# Altium schematic sheet Liquid level detection_v2.SchDoc
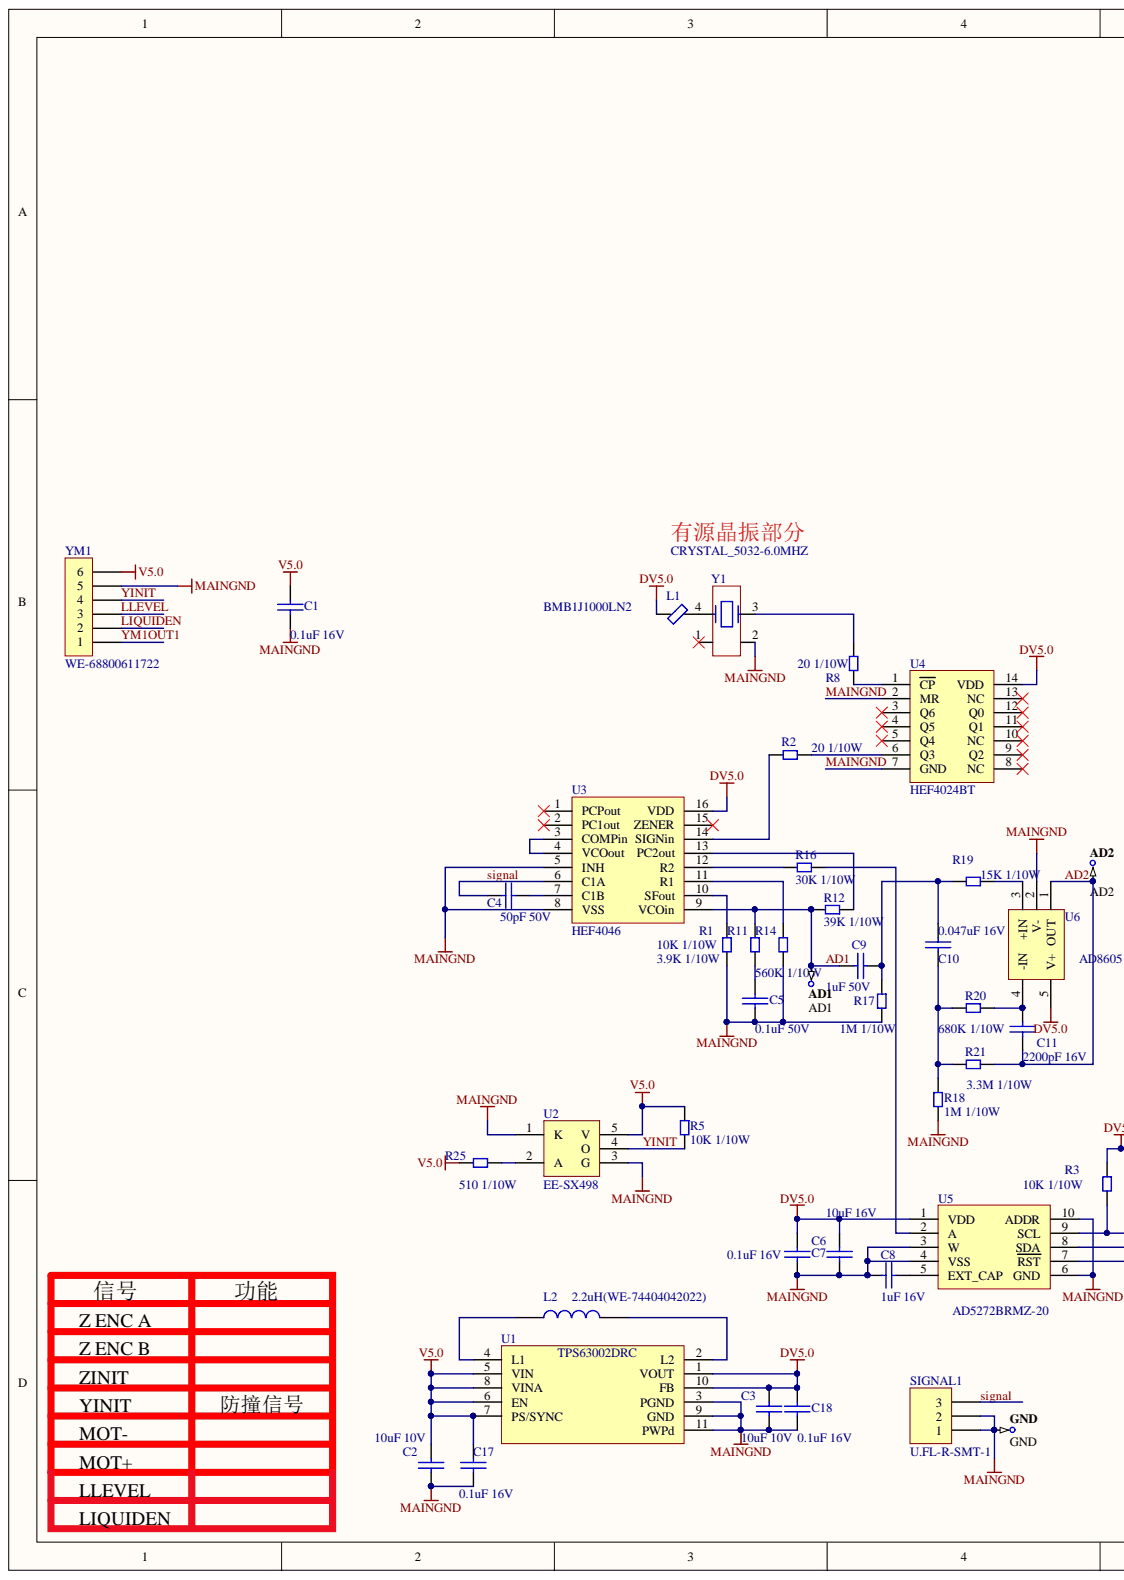
[diagram p0: Liquid level detection_v2.SchDoc, left]
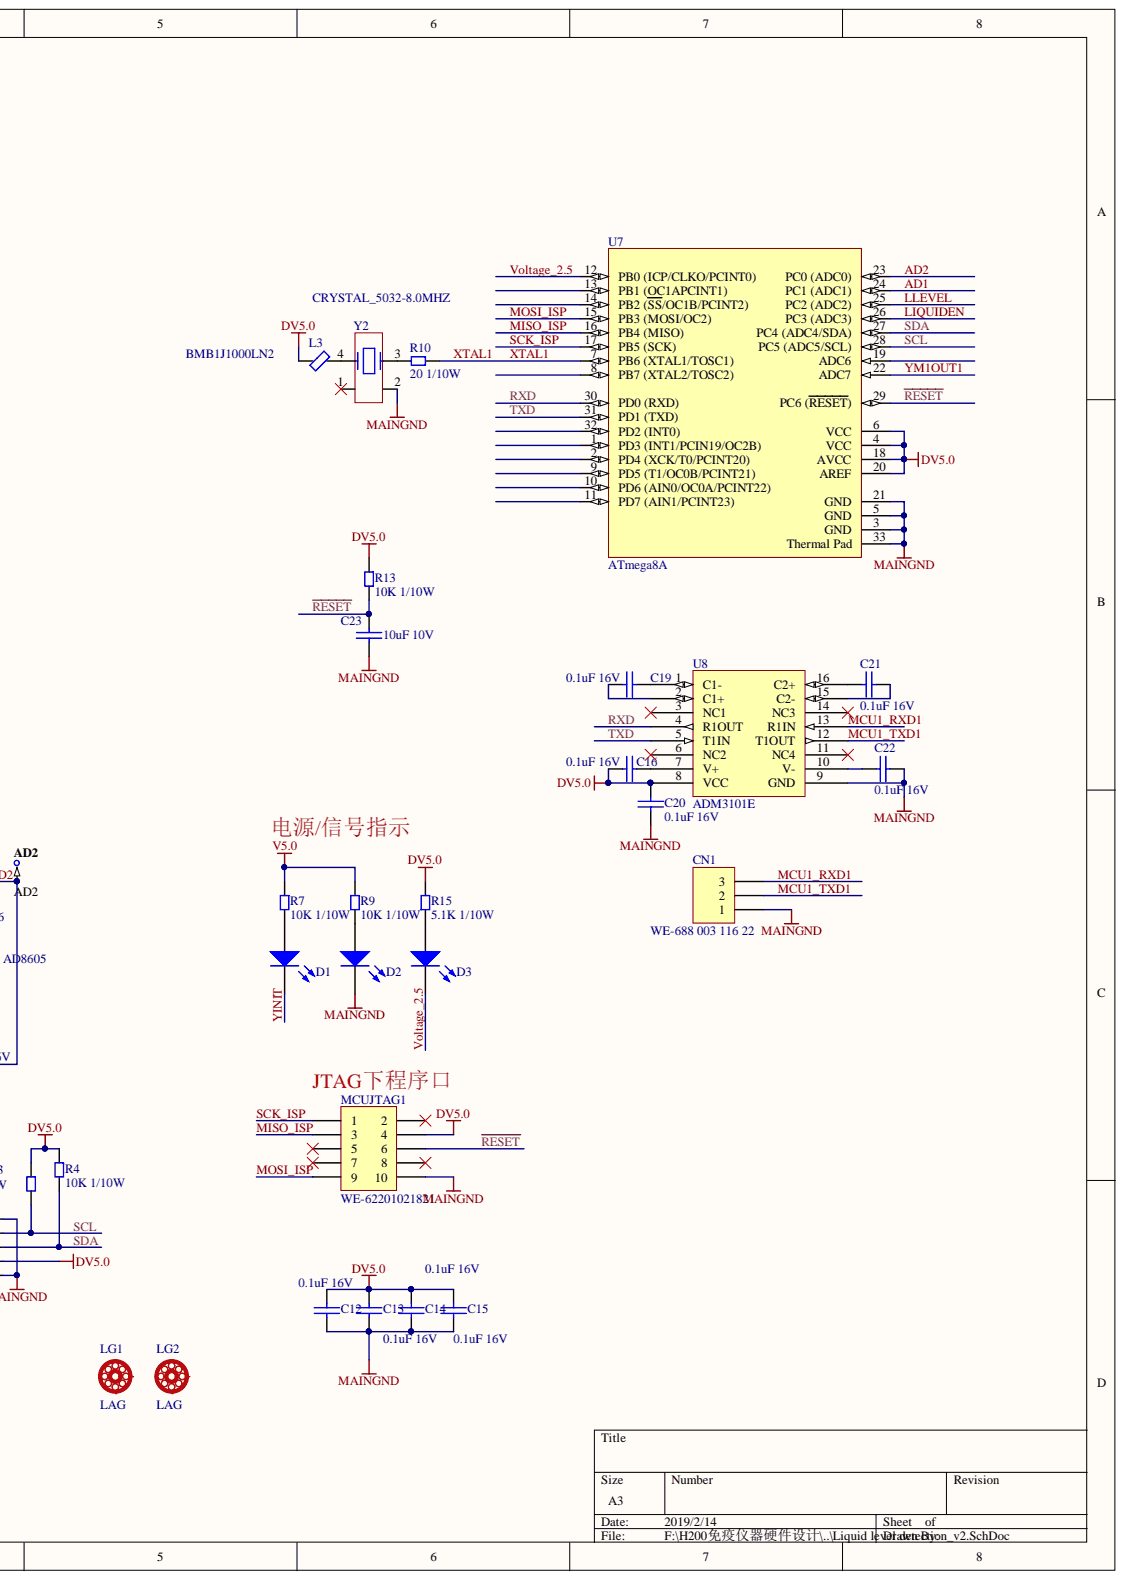
[diagram p1: Liquid level detection_v2.SchDoc, right]

## Parts
AD1  AD1  [TestPin]  (no BOM)
AD2  AD2  [TestPin]  (no BOM)
C1  0.1uF 16V  [C0603_104/50V]
C2  10uF 10V  [C0603_106/50V]
C3  10uF 10V  [C0603_106/50V]
C4  50pF 50V  [C0603_500/50V]
C5  0.1uF 50V  [C0603_104/50V]
C6  10uF 16V  [C0603_106/50V]
C7  0.1uF 16V  [C0603_104/50V]
C8  1uF 16V  [C0603_105/50V]
C9  1uF 50V  [C0603_104/50V]
C10  0.047uF 16V  [C0603_472/16V]
C11  2200pF 16V  [C0603_222/50V]
C12  0.1uF 16V  [C0603_104/50V]
C13  0.1uF 16V  [C0603_104/50V]
C14  0.1uF 16V  [C0603_104/50V]
C15  0.1uF 16V  [C0603_104/50V]
C16  0.1uF 16V  [C0603_104/50V]
C17  0.1uF 16V  [C0603_104/50V]
C18  0.1uF 16V  [C0603_104/50V]
C19  0.1uF 16V  [C0603_104/50V]
C20  0.1uF 16V  [C0603_104/50V]
C21  0.1uF 16V  [C0603_104/50V]
C22  0.1uF 16V  [C0603_104/50V]
C23  10uF 10V  [C0603_106/50V]
CN1  WE-688 003 116 22  [WR-WTB-2.5mm-3pin_68800311622]
D1  LED2  [LED_0805]  (value not drawn)
D2  LED2  [LED_0805]  (value not drawn)
D3  LED2  [LED_0805]  (value not drawn)
GND  GND  [TestPin]  (no BOM)
L1  BMB1J1000LN2  [0603_BMB2A1000LN2]
L2  2.2uH(WE-74404042022)  [WE-LQS-SMD4018_2.2uH]
L3  BMB1J1000LN2  [0603_BMB2A1000LN2]
LG1  LAG  [LAG3.0]
LG2  LAG  [LAG3.0]
MCUJTAG1  WE-62201021821  [1.27  2*5]
R1  10K 1/10W  [res0603_103]
R2  20 1/10W  [RES0603]
R3  10K 1/10W  [res0603_103]
R4  10K 1/10W  [res0603_103]
R5  10K 1/10W  [res0603_103]
R7  10K 1/10W  [res0603_103]
R8  20 1/10W  [res0603_200]
R9  10K 1/10W  [res0603_103]
R10  20 1/10W  [res0603_200]
R11  3.9K 1/10W  [RES0603]
R12  39K 1/10W  [RES0603]
R13  10K 1/10W  [RES0603]
R14  560K 1/10W  [RES0603]
R15  5.1K 1/10W  [res0603_512]
R16  30K 1/10W  [RES0603]
R17  1M 1/10W  [RES0603]
R18  1M 1/10W  [RES0603]
R19  15K 1/10W  [RES0603]
R20  680K 1/10W  [RES0603]
R21  3.3M 1/10W  [RES0603]
R25  510 1/10W  [RES0603]
SIGNAL1  U.FL-R-SMT-1  [U.FL-R-SMT-1]
U1  TPS63002DRC  [S-PVSON-N10_TPS63002DRC]
U2  EE-SX498  [EE-SX498]
U3  HEF4046  [D016_N]
U4  HEF4024BT  [SOIC-14]
U5  AD5272BRMZ-20  [MSOP10]
U6  AD8605  [SOT-23(RT-5)]
U7  ATmega8A  [32A_N]
U8  ADM3101E  [QSOP16]
Y1  CRYSTAL_5032-6.0MHZ  [CR-5032_24MHZ]
Y2  CRYSTAL_5032-8.0MHZ  [CR-5032_24MHZ]
YM1  WE-68800611722  [HDR254P1X6]

## Nets
DV5.0: C3.1 C6.1 C7.2 C12.2 C13.2 C14.2 C15.2 C16.2 C18.2 C20.1 L1.1 L3.1 MCUJTAG1.4 R3.1 R4.1 R13.1 R15.2 U1.1(VOUT) U1.10(FB) U3.16(VDD) U4.14(VDD) U5.1(VDD) U5.7(RST) U6.5(V+) U7.4(VCC) U7.6(VCC) U7.18(AVCC) U7.20(AREF) U8.8(VCC)
V5.0: C1.2 C2.1 C17.2 R5.1 R7.1 R9.1 R25.2 U1.5(VIN) U1.6(EN) U1.7(PS/SYNC) U1.8(VINA) U2.5(V) YM1.6
~{RESET}: C23.1 MCUJTAG1.6 R13.2 U7.29(PC6_(RESET))
AD1: AD1.1 C9.1 R11.1 R12.2 U3.9(VCOin) U7.24(PC1_(ADC1))
AD2: AD2.1 C11.2 R21.1 U6.1(OUT) U7.23(PC0_(ADC0))
LIQUIDEN: U7.26(PC3_(ADC3)) YM1.2
LLEVEL: U7.25(PC2_(ADC2)) YM1.3
MAINGND: C1.1 C2.2 C3.2 C5.1 C6.2 C7.1 C8.1 C12.1 C13.1 C14.1 C15.1 C17.1 C18.1 C20.2 C22.1 C23.2 CN1.1 D2.2 GND.1 MCUJTAG1.10 R1.2 R14.2 R17.2 R18.2 SIGNAL1.1 SIGNAL1.2 U1.3(PGND) U1.9(GND) U1.11(PWPd) U2.1(K) U2.3(G) U3.5(INH) U3.8(VSS) U4.2(MR) U4.7(GND) U5.3(W) U5.4(VSS) U5.6(GND) U5.10(ADDR) U6.2(V-) U7.3(GND) U7.5(GND) U7.21(GND) U7.33(Thermal_Pad) U8.9(GND) Y1.2 Y2.2 YM1.5
MCU1_RXD1: CN1.3 U8.13(R1IN)
MCU1_TXD1: CN1.2 U8.12(T1OUT)
MISO_ISP: MCUJTAG1.3 U7.16(PB4_(MISO))
MOSI_ISP: MCUJTAG1.9 U7.15(PB3_(MOSI/OC2))
RXD: U7.30(PD0_(RXD)) U8.4(R1OUT)
SCK_ISP: MCUJTAG1.1 U7.17(PB5_(SCK))
SCL: R3.2 U5.9(SCL) U7.28(PC5_(ADC5/SCL))
SDA: R4.2 U5.8(SDA) U7.27(PC4_(ADC4/SDA))
signal: C4.1 SIGNAL1.3 U3.6(C1A)
TXD: U7.31(PD1_(TXD)) U8.5(T1IN)
Voltage_2.5: D3.2 U7.12(PB0_(ICP/CLKO/PCINT0))
XTAL1: R10.1 U7.7(PB6_(XTAL1/TOSC1))
YINIT: D1.2 R5.2 U2.4(O) YM1.4
YM1OUT1: U7.22(ADC7) YM1.1
NetC4_2: C4.2 U3.7(C1B)
NetC5_2: C5.2 R11.2
NetC8_2: C8.2 U5.5(EXT_CAP)
NetC9_2: C9.2 C10.1 R17.1 R19.2
NetC10_2: C10.2 R18.1 R20.2 R21.2
NetC11_1: C11.1 R20.1 U6.4(-IN)
NetC16_1: C16.1 U8.7(V+)
NetC19_1: C19.1 U8.1(C1-)
NetC19_2: C19.2 U8.2(C1+)
NetC21_1: C21.1 U8.15(C2-)
NetC21_2: C21.2 U8.16(C2+)
NetC22_2: C22.2 U8.10(V-)
NetD1_1: D1.1 R7.2
NetD2_1: D2.1 R9.2
NetD3_1: D3.1 R15.1
NetL1_2: L1.2 Y1.4
NetL2_1: L2.1 U1.4(L1)
NetL2_2: L2.2 U1.2(L2)
NetL3_2: L3.2 Y2.4
NetR1_1: R1.1 U3.10(SFout)
NetR2_1: R2.1 U4.6(Q3)
NetR2_2: R2.2 U3.14(SIGNin)
NetR8_1: R8.1 U4.1(CP)
NetR8_2: R8.2 Y1.3
NetR10_2: R10.2 Y2.3
NetR12_1: R12.1 U3.13(PC2out)
NetR14_1: R14.1 U3.11(R1)
NetR16_1: R16.1 U5.2(A)
NetR16_2: R16.2 U3.12(R2)
NetR19_1: R19.1 U6.3(+IN)
NetR25_1: R25.1 U2.2(A)
NetU3_3: U3.3(COMPin) U3.4(VCOout)

Not connected: LG1 0; LG2 0; MCUJTAG1 2 5 7 8; U3 1(PCPout) 2(PC1out) 15(ZENER); U4 3(Q6) 4(Q5) 5(Q4) 8(NC) 9(Q2) 10(NC) 11(Q1) 12(Q0) 13(NC); U7 1(PD3_(INT1/PCIN19/OC2B)) 2(PD4_(XCK/T0/PCINT20)) 8(PB7_(XTAL2/TOSC2)) 9(PD5_(T1/OC0B/PCINT21)) 10(PD6_(AIN0/OC0A/PCINT22)) 11(PD7_(AIN1/PCINT23)) 13(PB1_(OC1APCINT1)) 14(PB2_(SS/OC1B/PCINT2)) 19(ADC6) 32(PD2_(INT0)); U8 3(NC1) 6(NC2) 11(NC4) 14(NC3); Y1 1; Y2 1

## Library data of the parts
AD1 AD2 GND = D-006-0000: Vero Technologies   20-313140; Category: Test-Point; Library Name: AltiumDesignLibrary.DesignLib; Status: Production
C1-C5 C7-C23 = CAP: SAMSUNG MUL Ceramiac  5%; Component Kind: Standard
C6 = CAP: AVX TAN; Component Kind: Standard
CN1 = Header 3: Header, 3-Pin; Component Kind: Standard; LatestRevisionDate: 17-Jul-2002; LatestRevisionNote: Re-released for DXP Platform.; Library Name: Miscellaneous Connectors.SchLib; Signal Integrity: Connector; Publisher: Altium Limited
D1-D3 = LED2: Typical  GREEN,  GaAs LED; Component Kind: Standard; LatestRevisionDate: 17-Jul-2002; LatestRevisionNote: Re-released for DXP Platform.; Library Name: Miscellaneous Devices.IntLib; PackageDescription: SM LED; 2 Flat Leads; PackageReference: 3.2X1.6X1.1; PackageVersion: Feb-2002; Published: 8-Jun-2000; Publisher: Altium Limited
L1 L3 = FERRITE BEAD: EMIFIL (Inductor type) Chip Ferrite Bead BMB2A Series (0603 Size); Component Kind: Standard
L2 = INDUCTOR: WE-LQS  SMD Shielded Tiny Power Inductor; Component Kind: Standard
LG1 LG2 = LAGVAL: Component Kind: Standard; Library Name: 3DGeneral.SCHLIB
MCUJTAG1 = MHDR2X5: WR-PHD THT Straight Dual Socket Header; Component Kind: Standard; LatestRevisionDate: 17-Jul-2002; LatestRevisionNote: Re-released for DXP Platform.; Library Name: Miscellaneous Connectors.SchLib; Signal Integrity: Connector; Publisher: Altium Limited
R1-R5 R7-R21 R25 = RES2: YAGEO Chip Res 1/10W 1%; Component Kind: Standard
SIGNAL1 = Header 3: U.FL Series Ultra Small Surface Mount Coaxial Connectors-2.4mm Mated Height; Component Kind: Standard; LatestRevisionDate: 17-Jul-2002; LatestRevisionNote: Re-released for DXP Platform.; Signal Integrity: Connector; Library Name: Liquid Level Detection.SCHLIB; Publisher: Altium Limited
U7 = ATmega328P-20AU: 8-Bit AVR Microcontroller with 8K Bytes of In-System Programmable Flash Memory; Code_JEDEC: MO-220-VHHD-2; Component Kind: Standard; ComponentLink1Description: Manufacturer Link; ComponentLink1URL: http://www.atmel.com; ComponentLink2Description: Datasheet; ComponentLink2URL: http://www.atmel.com/dyn/resources/prod_documents/doc2486.pdf; DatasheetVersion: 2486H-AVR-09/02; LatestRevisionDate: 13-Apr-2006; LatestRevisionNote: IPC-7351 Footprint Added.; PackageDescription: 32-Pin Quad Flat No-Lead 0.5 mm Pitch; PackageReference: 32M1-A; PackageVersion: revB, Aug-2001; Published: 26-May-2004; Library Name: Liquid Level Detection.SCHLIB; Publisher: Altium Limited
U8 = ADM3101E: ±15KV ESD Protected, 3.0V to 5.5V, 250kbps, 1 Tx/2 Rx, RS-232 Transceiver; Component Kind: Standard; Library Name: Liquid Level Detection.SCHLIB
Y1 Y2 = CRYSTAL_5032: SG5032CAN24.0MHZTJGA; Component Kind: Standard; Library Name: 2017.4.24 MainBoard.SchLib
YM1 = CON6: WR-WTB J Type Male Horizontal Shrouded Header; Component Kind: Standard; Library Name: 2015.10.1.SCHLIB
U1 = TPS63002DRC: Component Kind: Standard; Library Name: 2015.10.1.SCHLIB
U2 = EE-SX498: Component Kind: Standard; Library Name: 2015.10.1.SCHLIB
U3 = HEF4046: Component Kind: Standard; Library Name: 2015.10.1.SCHLIB
U4 = HEF4024BT: Component Kind: Standard; Library Name: 2015.10.1.SCHLIB
U5 = AD5272BRMZ-20: Component Kind: Standard; Library Name: 2015.10.1.SCHLIB
U6 = AD8605: Component Kind: Standard; Library Name: 2015.10.1.SCHLIB
